# Altium schematic sheet Relay.SchDoc(Relay)
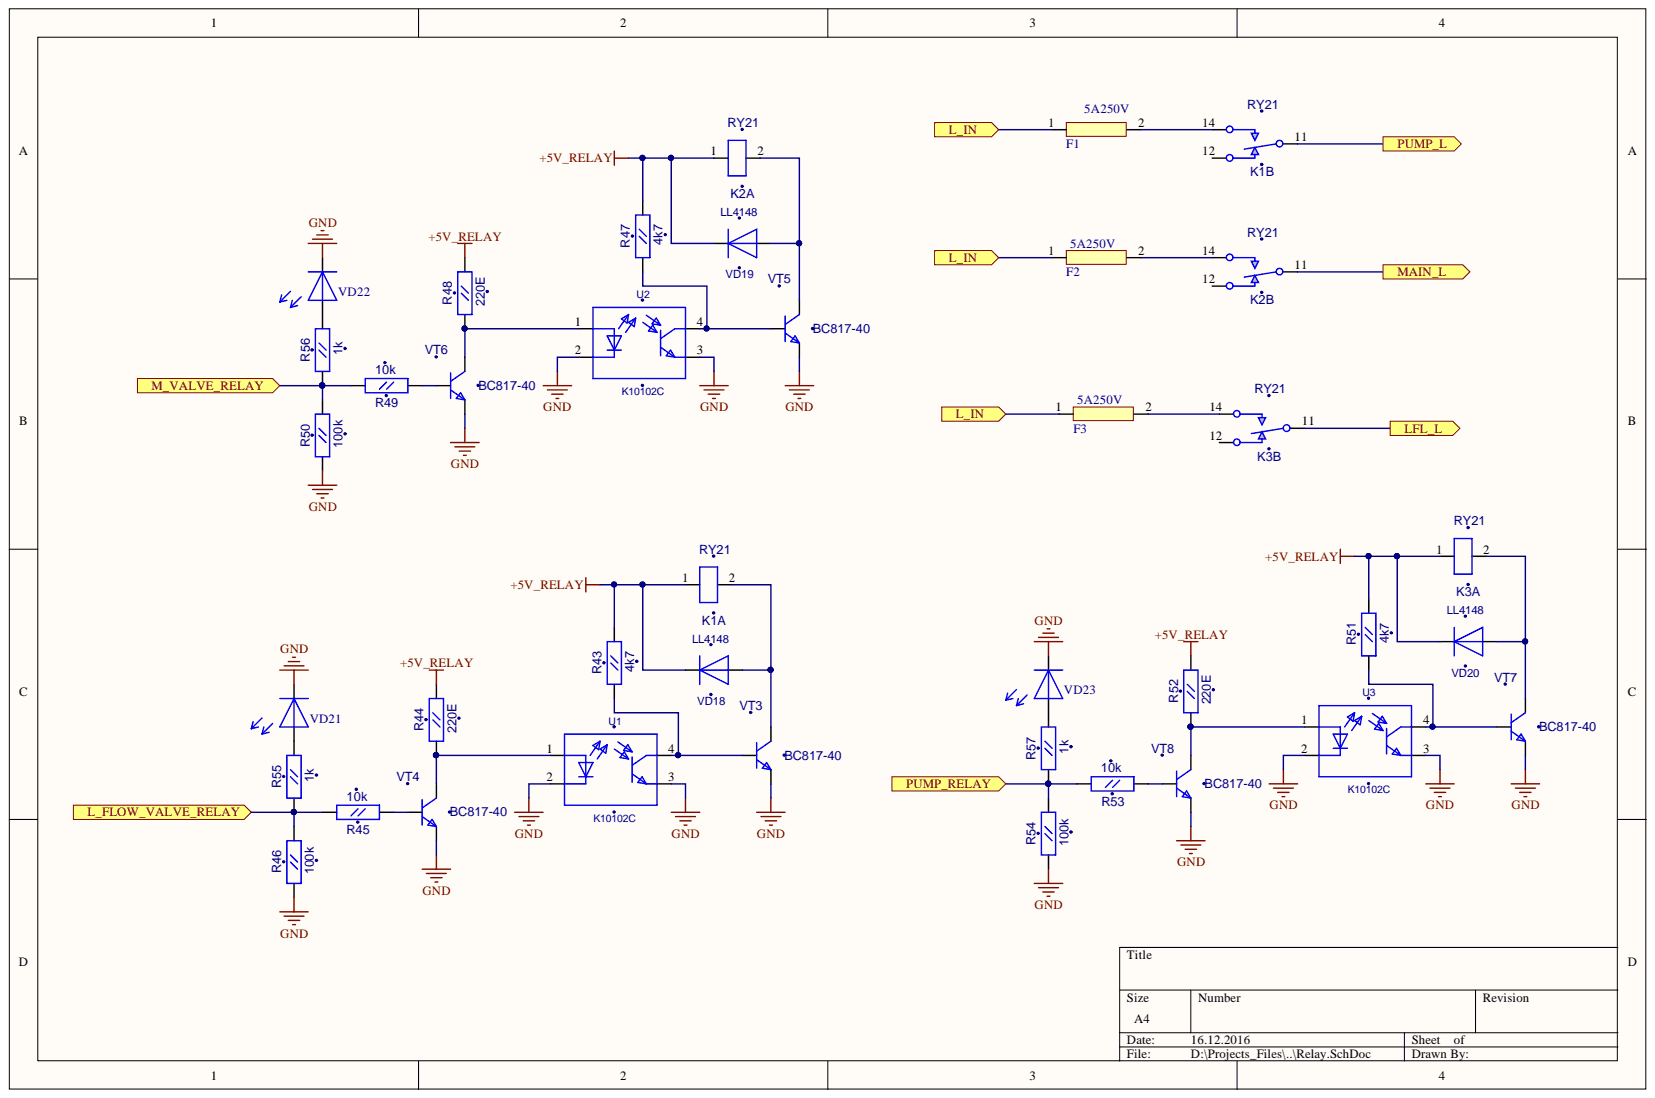
[diagram p0: Relay.SchDoc]

## Parts
F1  KLS5-266(5x20) 2pcs  [Fuse_5x20]  (value not drawn)
F2  KLS5-266(5x20) 2pcs  [Fuse_5x20]  (value not drawn)
F3  KLS5-266(5x20) 2pcs  [Fuse_5x20]  (value not drawn)
K1  RY21  [RY21_RY21(Primary)]  (parts A,B)
K2  RY21  [RY21_RY21(Primary)]  (parts A,B)
K3  RY21  [RY21_RY21(Primary)]  (parts A,B)
R43  4k7  [RC0603]
R44  220E  [RC0603]
R45  10k  [RC0603]
R46  100k  [RC0603]
R47  4k7  [RC0603]
R48  220E  [RC0603]
R49  10k  [RC0603]
R50  100k  [RC0603]
R51  4k7  [RC0603]
R52  220E  [RC0603]
R53  10k  [RC0603]
R54  100k  [RC0603]
R55  1k  [RC0603]
R56  1k  [RC0603]
R57  1k  [RC0603]
U1  K10102C  [PC817]
U2  K10102C  [PC817]
U3  K10102C  [PC817]
VD18  LL4148  [SOD-80]
VD19  LL4148  [SOD-80]
VD20  LL4148  [SOD-80]
VD21  LED_0805  [LED0805]  (value not drawn)
VD22  LED_0805  [LED0805]  (value not drawn)
VD23  LED_0805  [LED0805]  (value not drawn)
VT3  BC817-40  [SOT-23(123)]
VT4  BC817-40  [SOT-23(123)]
VT5  BC817-40  [SOT-23(123)]
VT6  BC817-40  [SOT-23(123)]
VT7  BC817-40  [SOT-23(123)]
VT8  BC817-40  [SOT-23(123)]

## Ports
L_FLOW_VALVE_RELAY, L_IN, LFL_L, M_VALVE_RELAY, MAIN_L, PUMP_L, PUMP_RELAY

## Nets
+5V_RELAY: K1.1 K2.1 K3.1 R43.2 R44.2 R47.2 R48.2 R51.2 R52.2 VD18.2 VD19.2 VD20.2
GND: R46.1 R50.1 R54.1 U1.2 U1.3 U2.2 U2.3 U3.2 U3.3 VD21.2 VD22.2 VD23.2 VT3.2 VT4.2 VT5.2 VT6.2 VT7.2 VT8.2
L_FLOW_VALVE_RELAY: R45.2 R46.2 R55.1 ; port L_FLOW_VALVE_RELAY
L_IN: F1.1 F2.1 F3.1 ; port L_IN
LFL_L: K3.11 ; port LFL_L
M_VALVE_RELAY: R49.2 R50.2 R56.1 ; port M_VALVE_RELAY
MAIN_L: K2.11 ; port MAIN_L
PUMP_L: K1.11 ; port PUMP_L
PUMP_RELAY: R53.2 R54.2 R57.1 ; port PUMP_RELAY
NetF1_2: F1.2 K1.14
NetF2_2: F2.2 K2.14
NetF3_2: F3.2 K3.14
NetK1_2: K1.2 VD18.1 VT3.3
NetK2_2: K2.2 VD19.1 VT5.3
NetK3_2: K3.2 VD20.1 VT7.3
NetR43_1: R43.1 U1.4 VT3.1
NetR44_1: R44.1 U1.1 VT4.3
NetR45_1: R45.1 VT4.1
NetR47_1: R47.1 U2.4 VT5.1
NetR48_1: R48.1 U2.1 VT6.3
NetR49_1: R49.1 VT6.1
NetR51_1: R51.1 U3.4 VT7.1
NetR52_1: R52.1 U3.1 VT8.3
NetR53_1: R53.1 VT8.1
NetR55_2: R55.2 VD21.1
NetR56_2: R56.2 VD22.1
NetR57_2: R57.2 VD23.1

Not connected: K1 12; K2 12; K3 12

## Library data of the parts
F1-F3 = Fuse KLS5-266(5x20): Component Kind: Standard; Value: KLS5-266(5x20) 2pcs; Library Name: gprs_modem_2.SchLib
R43-R57 = RC0603: Component Kind: Standard; Description: RC0603; Library Name: gprs_modem_2.SchLib
  R43 R47 R51: Value: 4k7
  R44 R48 R52: Value: 220E
  R45 R49 R53: Value: 10k
  R46 R50 R54: Value: 100k
  R55-R57: Value: 1k
VT3-VT8 = BC846BLT1: NPN 500ma transistor; ComponentField7: Published 29-Sep-2000; Component Kind: Standard; OriginalName: BC846BLT1; Simulation: BC846BLT1; Value: BC817-40; Library Name: gprs_modem_2.SchLib
K1-K3 = RY21: Component Kind: Standard; Description: RY21; Library Name: shlagbaum.SchLib
U1-U3 = K10102C: Component Kind: Standard; Description: K10102C; Library Name: gprs_modem_2.SchLib
VD18-VD20 = LL4148: Component Kind: Standard; Description: LL4148; Library Name: gprs_modem_2.SchLib
VD21-VD23 = LED_0805: Component Kind: Standard; Value: LED_0805; Library Name: gprs_modem_2.SchLib
